# Altium schematic sheet SB_Main.SchDoc
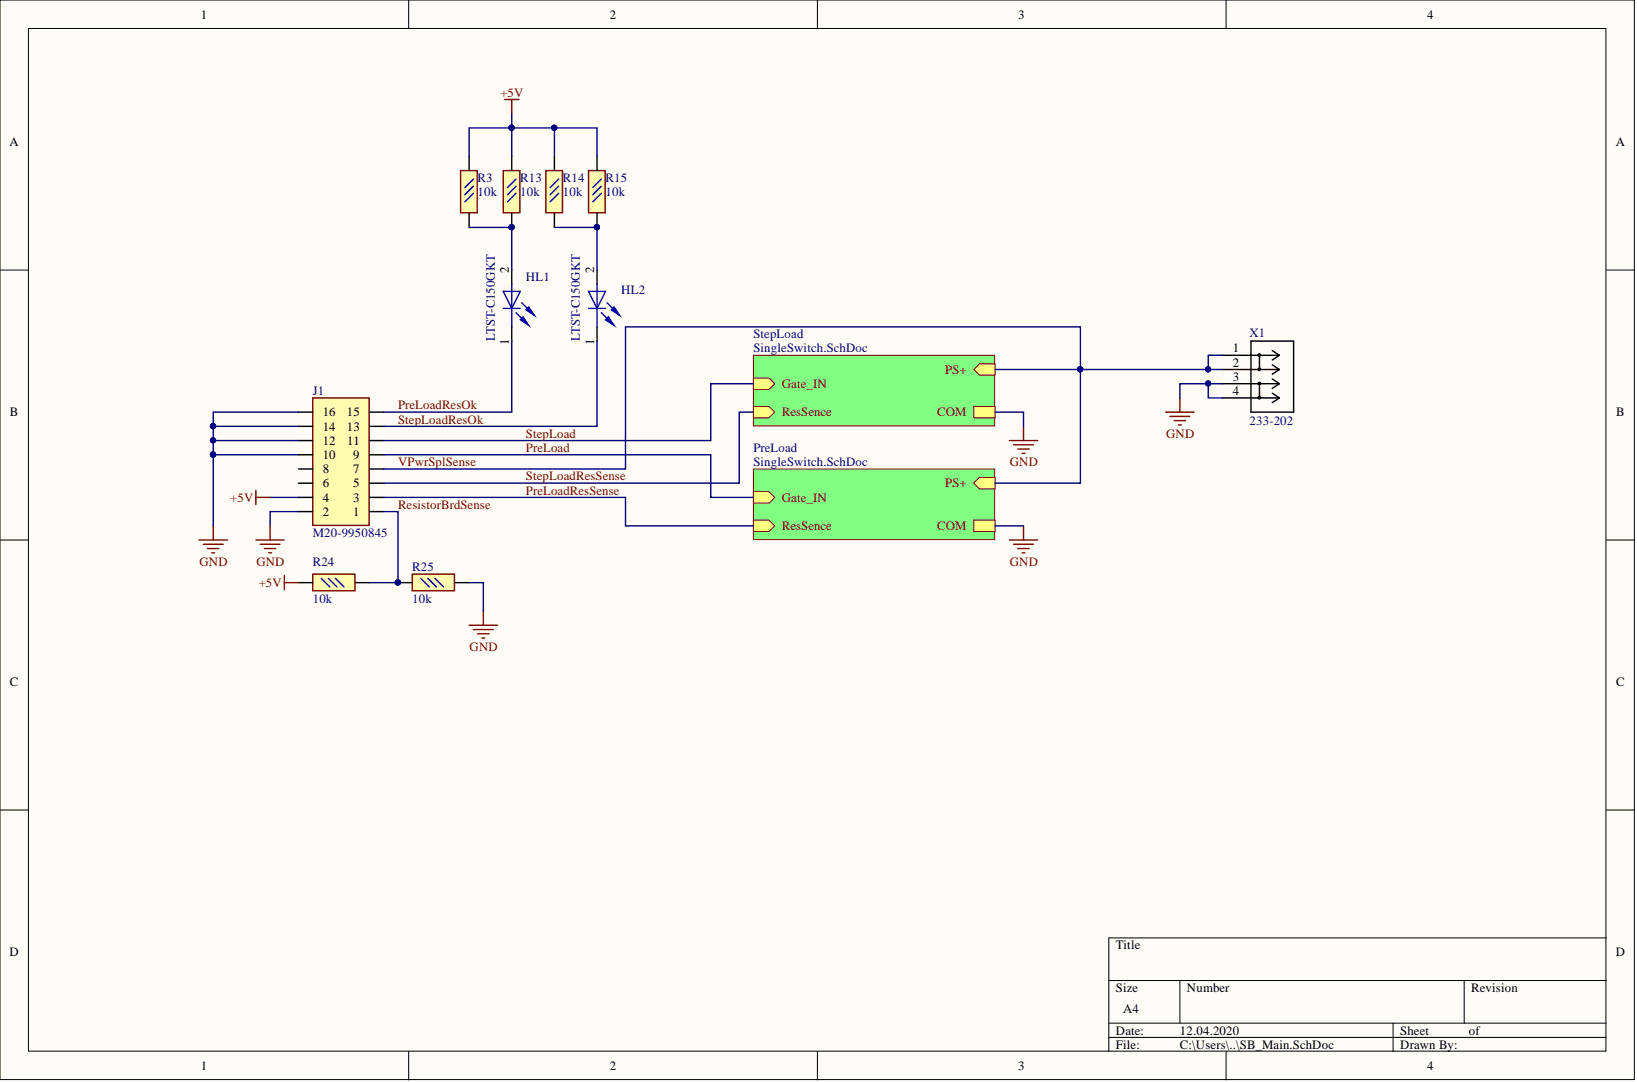
[diagram p0: SB_Main.SchDoc]

## Parts
HL1  LTST-C150GKT  [LED1206_RED]
HL2  LTST-C150GKT  [LED1206_RED]
J1  M20-9950845  [M20-9950845]
R3  10k  [0603r]
R13  10k  [0603r]
R14  10k  [0603r]
R15  10k  [0603r]
R24  10k  [0603r]
R25  10k  [0603r]
X1  233-202  [CONN_233-102]

## Nets
+5V: J1.4 R3.1 R13.1 R14.1 R15.1 R24.1
GND: J1.2 J1.10 J1.12 J1.14 J1.16 R25.2 X1.3 X1.4
PreLoad: J1.9
PreLoadResOk: HL1.1 J1.15
PreLoadResSense: J1.3
ResistorBrdSense: J1.1 R24.2 R25.1
StepLoad: J1.11
StepLoadResOk: HL2.1 J1.13
StepLoadResSense: J1.5
VPwrSplSense: J1.7 X1.1 X1.2
NetHL1_2: HL1.2 R3.2 R13.2
NetHL2_2: HL2.2 R14.2 R15.2

Not connected: J1 6 8

## Library data of the parts
HL1 HL2 = LTST-C150GKT: Standard LEDs - SMD Green Clear 569nm; Company: Lite-On; Type: Led; Component Kind: Standard; Library Name: DIODE_home.SchLib; Order Code: LTST-C150GKT; Supplier 1: Digi-Key; Supplier Part Number 1: 160-1169-6-ND; Value: Green
J1 = M20-9950845: 2 x 8pin 2.54 Male 90 deg; Company: Harwin; System: Connector; Component Kind: Standard; Mounting: TH; Order Code: M20-9950845; Supplier 1: Mouser; Supplier Part Number 1: 855-M20-9950845; Type: Connector; Value: M20-9950845; Library Name: SOEDINITELI_hOME.SchLib
R3 R13-R15 R24 R25 = 10k 0603 5%: Company: Yageo; ID: B112CV1113Y; Order Code: RC0603JR-0710KL; TSKD: FS-CM_StandDet_Resistor_SMD(10K_5%_100_0603); Component Kind: Standard; Description: 10k 0603 5%; Library Name: RESISTORs_Home.SchLib; Supplier 1: Digi-Key; Supplier Part Number 1: 311-10KGRCT-ND; Type: Resistor; Value: 10k
X1 = 233-202: PCB terminal block; push-button; 0.5 mm²; Pin spacing 2.5 mm; 2-pole; CAGE CLAMP®; 0,50 mm²; gray; Built_By: EMA_Colton; Company: Wago; Component Kind: Standard; Library Name: 2019-10-14_20-33-16.SchLib; Order Code: 233-202; RefDes: RefDes; Type: Connector; Value: Value; Copyright: Copyright (C) 2016 Accelerated Designs. All rights reserved
